# Altium schematic sheet Qube3.SchDoc
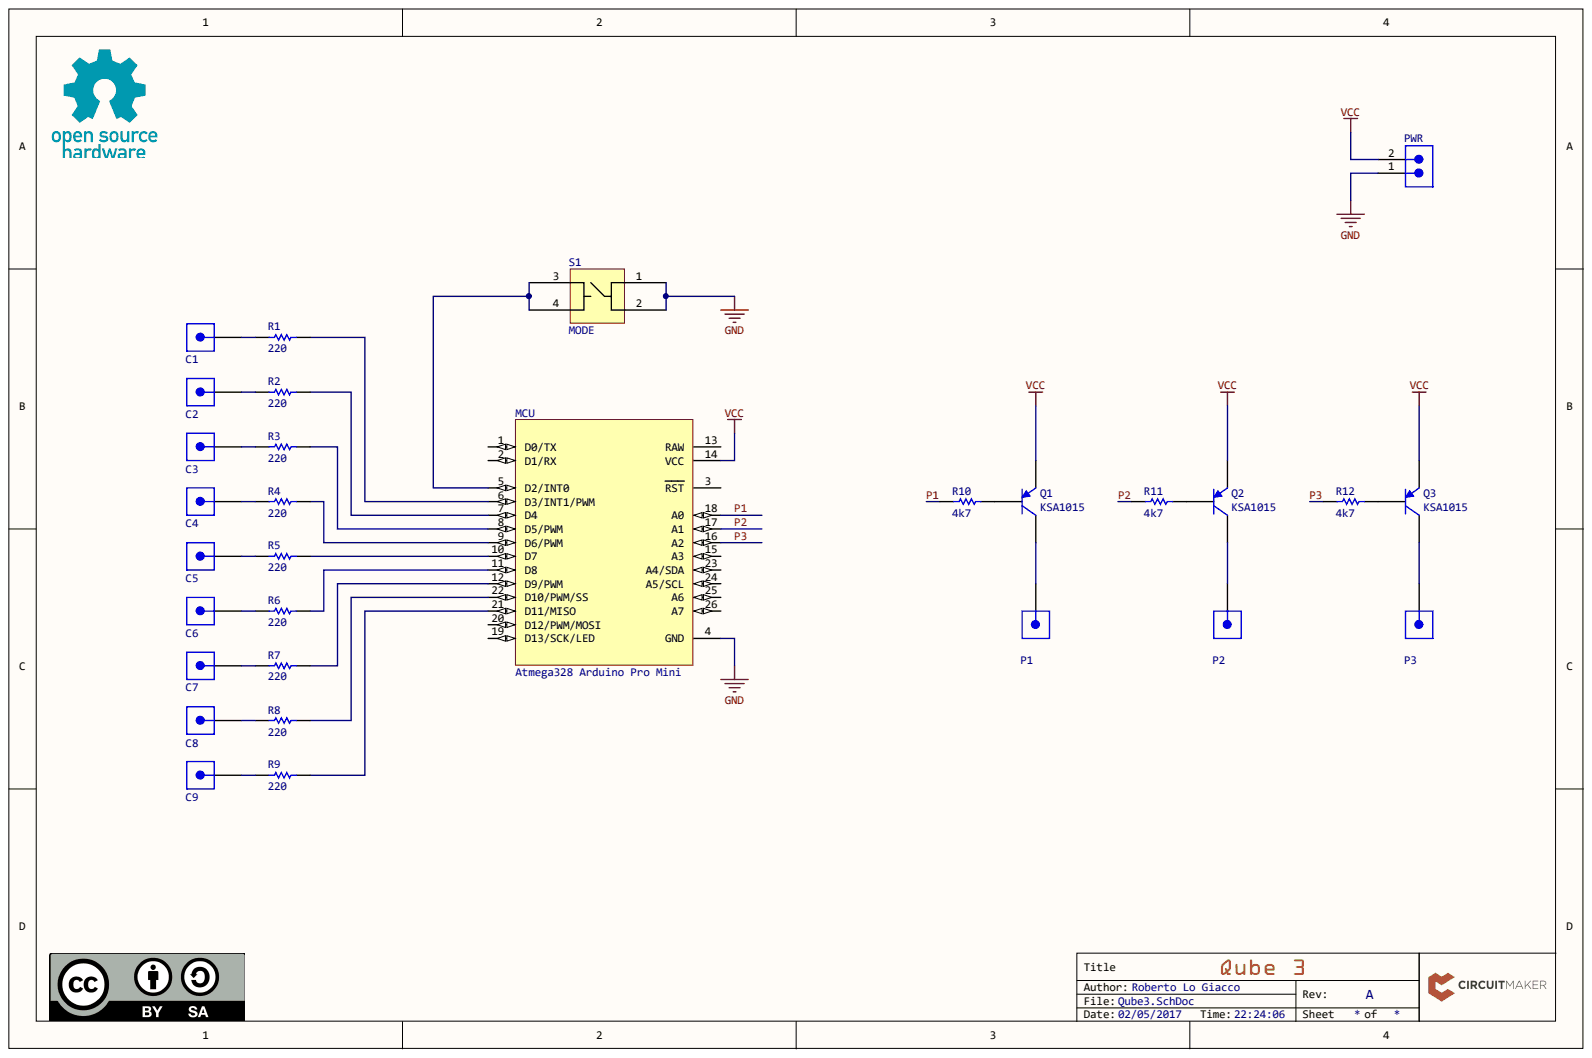
[diagram p0: Qube3.SchDoc]

## Parts
C1  Header_1x1  [PCB-ak2588anil9o8b8pmeqi-1]  (value not drawn)
C2  Header_1x1  [PCB-ak2588anil9o8b8pmeqi-1]  (value not drawn)
C3  Header_1x1  [PCB-ak2588anil9o8b8pmeqi-1]  (value not drawn)
C4  Header_1x1  [PCB-ak2588anil9o8b8pmeqi-1]  (value not drawn)
C5  Header_1x1  [PCB-ak2588anil9o8b8pmeqi-1]  (value not drawn)
C6  Header_1x1  [PCB-ak2588anil9o8b8pmeqi-1]  (value not drawn)
C7  Header_1x1  [PCB-ak2588anil9o8b8pmeqi-1]  (value not drawn)
C8  Header_1x1  [PCB-ak2588anil9o8b8pmeqi-1]  (value not drawn)
C9  Header_1x1  [PCB-ak2588anil9o8b8pmeqi-1]  (value not drawn)
MCU  Atmega328 Arduino Pro Mini  [PCB-d9ot7xhi8p193qdo5n9i-1]
P1  Header_1x1  [PCB-ak2588anil9o8b8pmeqi-1]  (value not drawn)
P2  Header_1x1  [PCB-ak2588anil9o8b8pmeqi-1]  (value not drawn)
P3  Header_1x1  [PCB-ak2588anil9o8b8pmeqi-1]  (value not drawn)
PWR  Samtec TSW-102-07-S-S  [HDR102]  (value not drawn)
Q1  KSA1015  [PCB-le84lx4691bmj6ai9p3c-1]
Q2  KSA1015  [PCB-le84lx4691bmj6ai9p3c-1]
Q3  KSA1015  [PCB-le84lx4691bmj6ai9p3c-1]
R1  220  [compact]
R2  220  [compact]
R3  220  [compact]
R4  220  [compact]
R5  220  [compact]
R6  220  [compact]
R7  220  [compact]
R8  220  [compact]
R9  220  [compact]
R10  4k7  [compact]
R11  4k7  [compact]
R12  4k7  [compact]
S1  MODE  [TACTILE-PTH]

## Nets
GND: MCU.4(GND) PWR.1 S1.1 S1.2
VCC: MCU.14(VCC) PWR.2 Q1.1 Q2.1 Q3.1
P1: MCU.18(A0) R10.1
P2: MCU.17(A1) R11.1
P3: MCU.16(A2) R12.1
NetC1_1: C1.1 R1.1
NetC2_1: C2.1 R2.1
NetC3_1: C3.1 R3.1
NetC4_1: C4.1 R4.1
NetC5_1: C5.1 R5.1
NetC6_1: C6.1 R6.1
NetC7_1: C7.1 R7.1
NetC8_1: C8.1 R8.1
NetC9_1: C9.1 R9.1
NetMCU_5: MCU.5(D2/INT0) S1.3 S1.4
NetMCU_6: MCU.6(D3/INT1/PWM) R1.2
NetMCU_7: MCU.7(D4) R2.2
NetMCU_8: MCU.8(D5/PWM) R3.2
NetMCU_9: MCU.9(D6/PWM) R4.2
NetMCU_10: MCU.10(D7) R5.2
NetMCU_11: MCU.11(D8) R6.2
NetMCU_12: MCU.12(D9/PWM) R7.2
NetMCU_21: MCU.21(D11/MISO) R9.2
NetMCU_22: MCU.22(D10/PWM/SS) R8.2
NetP1_1: P1.1 Q1.2
NetP2_1: P2.1 Q2.2
NetP3_1: P3.1 Q3.2
NetQ1_3: Q1.3 R10.2
NetQ2_3: Q2.3 R11.2
NetQ3_3: Q3.3 R12.2

Not connected: MCU 1(D0/TX) 2(D1/RX) 3(RST) 13(RAW) 15(A3) 19(D13/SCK/LED) 20(D12/PWM/MOSI) 23(A4/SDA) 24(A5/SCL) 25(A6) 26(A7)

## Library data of the parts
C1-C9 P1-P3 = CMP-3982129-4: CONN HEADER 1POS .100" SNGL TIN; Component Kind: Standard; Library Name: CommunityVault
MCU = Atmega328 Arduino Pro Mini-15: Manufacturer Part Number: DEV-11114; Component Kind: Standard; Description: Atmega328 Arduino Pro Mini; Library Name: FavoritesLibrary; OctopartID: c37d092409061cba; Octopart URL: http://octopart.com/dev-11114-sparkfun-55832349
PWR = CMP-4501201-2: CONN HEADER 2POS .100" SGL GOLD; Gender: Male; Lead-Free Status: Lead Free; Number of Contacts: 2; Pin Pitch: 0.00254 m; Component Kind: Standard; Contact Material: Phosphor Bronze; Contact Plating: Gold; Housing Color: Black; Housing Material: Plastic; Library Name: CommunityVault; Mounting Style: Through Hole; Number of Positions: 2; Number of Rows: 1; OctopartID: 2036e9382d5dfff1; Octopart Url: https://octopart.com/tsw-102-07-s-s-samtec-272397; RoHS: Compliant; Size-Height: 0.00254 m; Size-Length: 0.00508 m; Size-Width: 0.00254 m
Q1-Q3 = CMP-08b0c1bf5cefd777-1: KSA1015 Series 50 V 150 mA Through Hole PNP Epitaxial Silicon Transistor-TO-92-3; Manufacturer Part Number: KSA1015YTA; Current Rating: -150 mA; Lead-Free Status: Lead Free; Voltage Rating (DC): -50.0 V; Case/Package: TO-92; Component Kind: Standard; Library Name: FavoritesLibrary; Lifecycle Status: Active; Mounting Style: Through Hole; Number of Pins: 3; OctopartID: 08b0c1bf5cefd777; Octopart URL: http://octopart.com/ksa1015yta-fairchild+semiconductor-356665; Packaging: Tape; Polarity: PNP; REACH SVHC Compliance: No SVHC; RoHS: Compliant
R1-R12 = CMP-j5fhnfamf20d9ordjamr-3: Generic 1/4W Through-Hole Resistor; Package (Imperial): Axial 0.250W; Power Rating: 0.250W; Component Kind: Standard; CustomComponentID: j5fhnfamf20d9ordjamr; Library Name: CommunityVault
  R1-R9: Resistance: 220
  R10-R12: Resistance: 4k7
S1 = CMP-27b2ecd8449ff875-4: Switch Tactile N.O. SPST Round Button PC Pins 0.02A 15VDC 1N Thru-Hole Bulk; Manufacturer Part Number: EVQ-PBC04M; Actuator Type: Standard; Lead-Free Status: Lead Free; Component Kind: Standard; Contact Current Rating: 0.02 A; Contacts Type: SPST; Library Name: CommunityVault; Lifecycle Status: Not Listed by Manufacturer; Mounting Style: Through Hole; OctopartID: 27b2ecd8449ff875; Octopart URL: http://octopart.com/evq-pbc04m-panasonic-39863883; Orientation: Vertical; RoHS: Compliant; Size-Height: 0.0043 m; Size-Length: 0.006 m; Size-Width: 0.006 m
